# Altium schematic sheet main.SchDoc
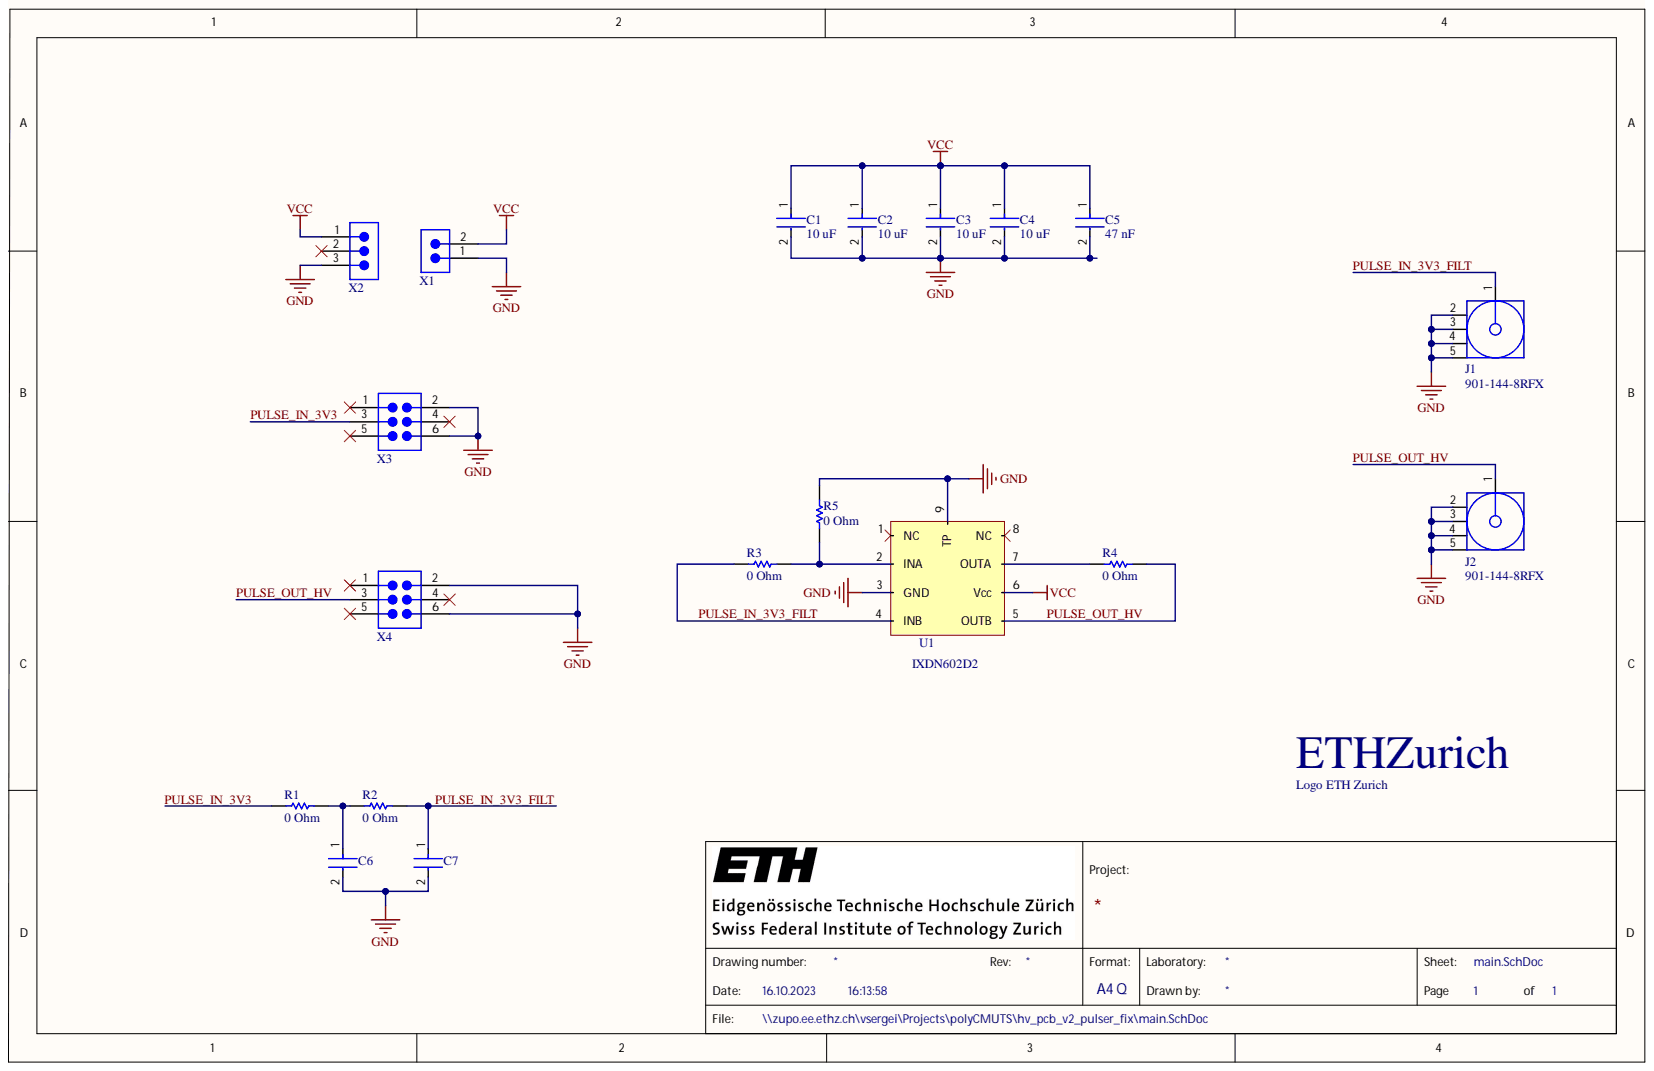
[diagram p0: main.SchDoc]

## Parts
A?  Logo ETH Zurich  [eth-zurich_2mm]  (no BOM)
C1  10 uF  [FP-GRM188-0_2-IPC_C]
C2  10 uF  [FP-GRM188-0_2-IPC_C]
C3  10 uF  [FP-GRM188-0_2-IPC_C]
C4  10 uF  [FP-GRM188-0_2-IPC_C]
C5  47 nF  [FP-CGAEA-MFG]
C6  C0402C100J3GACTU  [FP-C0402-BB-MFG]  (value not drawn)
C7  C0402C100J3GACTU  [FP-C0402-BB-MFG]  (value not drawn)
J1  901-144-8RFX  [AMPH-901-144-8RFX_V]
J2  901-144-8RFX  [AMPH-901-144-8RFX_V]
R1  0 Ohm  [RESC1005X40X25NL05T10]
R2  0 Ohm  [RESC1005X40X25NL05T10]
R3  0 Ohm  [RESC1005X40X25NL05T10]
R4  0 Ohm  [RESC1005X40X25NL05T10]
R5  0 Ohm  [RESC1005X40X25NL05T10]
U1  IXDN602D2  [IXDN602D2]
X1  68000-102HLF  [68000-102HLF]  (value not drawn)
X2  68000-103HLF  [68000-103HLF]  (value not drawn)
X3  67996-106HLF  [67996-106HLF]  (value not drawn)
X4  67996-106HLF  [67996-106HLF]  (value not drawn)

## Nets
GND: C1.2 C2.2 C3.2 C4.2 C5.2 C6.2 C7.2 J1.2 J1.3 J1.4 J1.5 J2.2 J2.3 J2.4 J2.5 R5.2 U1.3(GND) U1.9(TP) X1.1 X2.3 X3.2 X3.6 X4.2 X4.6
VCC: C1.1 C2.1 C3.1 C4.1 C5.1 U1.6(Vcc) X1.2 X2.1
PULSE_IN_3V3: R1.2 X3.3
PULSE_IN_3V3_FILT: C7.1 J1.1 R2.1 R3.1 U1.4(INB)
PULSE_OUT_HV: J2.1 R4.2 U1.5(OUTB) X4.3
NetC6_1: C6.1 R1.1 R2.2
NetR3_2: R3.2 R5.1 U1.2(INA)
NetR4_1: R4.1 U1.7(OUTA)

Not connected: U1 1(NC) 8(NC); X2 2; X3 1 4 5; X4 1 4 5

## Library data of the parts
A? = CMP-00038-1: Library Name: ETHZ Vault
C1-C4 = CMP-06035-042125-1: Chip Multilayer Ceramic Capacitors for General Purpose, 0603, 2.2uF, X5R, 15%, 10%, 50V GRM188R61H225KE11D; Capacitance: 2.2uF; Case Code (Imperial): 0603; Case Code (Metric): 1608; Case/Package: 0603; Component Kind: Standard; Dielectric: X5R; Height: 0.8mm; Length: 0.063inch; Library Name: Altium Content Vault; Material: Ceramic; Max Operating Temperature: 85°C; Min Operating Temperature: -55°C; Mount: Surface Mount; Packaging: Cut Tape; REACH SVHC: No SVHC; Thickness: 0.039inch; Tolerance: 10%; Voltage Rating: 50V; Voltage Rating (DC): 50V; Width: 0.031inch
C5 = CMP-08246-002687-1: CAP CER 0.047UF 50V X7R 0204  CGAEA1X7R1H473M030BC; Component Kind: Standard; Library Name: Altium Content Vault; RoHS Compliant: No
C6 C7 = CMP-1034-00716-4: CAP CER 10PF 25V 5% NP0 0402; Manufacturer: Kemet; Material: Ceramic; Temperature Characteristic: C0G; Temperature Coefficient: 15%; Tolerance: 0.05; Automotive: No; Capacitance: 10 pF; Category: Cap; Component Kind: Standard; ComponentLink2Description: Datasheet; ComponentLink2URL: https://content.kemet.com/datasheets/KEM_C1002_X7R_SMD.pdf; Device Class L1: Passive Components; Device Class L2: Capacitors; Device Class L3: Ceramic Capacitors; Digikey Description: CAP CER 10PF 25V 5% NP0 0402; Height: 0.55mm; Ipc Land Pattern Name: CAPC10050X50; Lead Free: Yes; Library Name: Altium Content Vault; Manufacturer Part Number: C0402C100J3GACTU; Mouser Description: Multilayer Ceramic Capacitors MLCC - SMD/SMT 25volts 10pF C0G 5%; Octopart: https://octopart.com/c0402c100j3gactu-kemet-9138549; Package: C0402-BB; RoHS: TRUE; Temperature: -55°C to +125°C; Temperature Range High: +125°C; Temperature Range Low: -55°C; Value: 10 pF; Voltage Rating: 25 V
J1 J2 = CMP-2000-05706-1: Coaxial Connector, 18 GHz, 50 Ohm, -65 to 165 degC, 5-Pin THD, RoHS, Bulk; Manufacturer: Amphenol; 3D Model: 901-144-8RFX.igs; Body Finish: Gold; Body Material: Brass; Center Contact Material: BerylliumCopper; Connector Style: SMA; Connector Type: Jack,FemaleSocket; Contact Termination: Solder; Dielectric Material: Polytetrafluoroethylene(PTFE); Frequency - Max: 18GHz; Impedance: 50Ohm; Online Catalog: SMA,RFX; Other Names: 901-144-8-RFX9011448RFXARFX1231; Cable Group: -; Category: Connectors,Interconnects; Center Contact Plating: Gold; Component Kind: Standard; Datasheet URL: https://datasheet.ciiva.com/23676/c901-144-8rfx-23676337.pdf; Datasheet Version: Rev. A, 01/2001; Family: CoaxialConnectors(RF); Fastening Type: Threaded; Features: -; Housing Color: Gold; Ingress Protection: -; Library Name: Altium Content Vault; Manufacturer URL: http://www.amphenol.com/; Mounting Technology: SurfaceMount; Mounting Type: ThroughHole; Operating Temperature: -65°C~165°C; Package Description: 5-Pin Through Hole Device, Body 7 x 7 mm, Pitch 2.54 mm; Package Reference: 901-144-8RFX; Packaging: Bulk; Series: -; Shield Termination: Solder; Standard Package: 1; Voltage Rating: -
R1-R5 = CMP-1011-00001-2: Manufacturer: Yageo / Phycomp; Part Number: RC0402JR-070RL; Component Kind: Standard; Library Name: Altium Content Vault
U1 = IXDN602D2: IXD_602  2-Ampere Dual Low-Side  Ultrafast MOSFET Drivers; Component Kind: Standard; Library Name: Schlib.SchLib
X1 = CMP-00100-3: Manufacturer: Amphenol FCI; Connector Type: Male Pin; Current Rating: 3A; Gender: Male; Insulation Resistance: 5GR; Mating Post Length: 5.84mm; Max Voltage Rating (AC): 1.5kV; Number of Contacts: 2; Pitch: 2.54mm; Case/Package: 100; Colour: Black; Component Kind: Standard; ComponentLink1Description: 68000-274228-5149165.pdf; ComponentLink1URL: https://datasheet.ciiva.com/5149/68000-274228-5149165.pdf; ComponentLink2Description: 68000-722084.pdf; ComponentLink2URL: https://datasheet.ciiva.com/722/68000-722084.pdf; ComponentLink3Description: 68000-10149324.pdf; ComponentLink3URL: https://datasheet.ciiva.com/10149/68000-10149324.pdf; ComponentLink4Description: 68000-16726309.pdf; ComponentLink4URL: https://datasheet.ciiva.com/16726/68000-16726309.pdf; Contact Gender: Male; Contact Material: Bronze; Contact Mating Length: 0.23inch; Contact Plating: Nickel,Gold,GXT; Depth: 2.41mm; Flammability Rating: UL94 V-0; Housing Colour: Black; Housing Material: Thermoplastic; Lead Free: Lead Free; Length: 5.08mm; Library Name: ETHZ Vault; Manufacturer Part Number: 68000-102HLF; Max Operating Temperature: 125°C; Min Operating Temperature: -65°C; Number of Positions: 2; Number of Rows: 1; Orientation: Straight; Package Quantity: 100; Packaging: Bulk; Radiation Hardening: No; Supplier: Digikey; Supplier Part Number: 609-3500-ND; Termination: Solder; Termination Post Length: 2.41mm; Voltage Rating: 1.5kV; Voltage Rating (DC): 1.5kV
X2 = CMP-00101-2: 3 Positions Header, Unshrouded Connector 0.100" (2.54mm) Through Hole Gold or Gold, GXT™; Manufacturer: Amphenol FCI; Current Rating: 3A; Insulation Resistance: 5GR; Max Voltage Rating (AC): 1.5kV; Number of Contacts: 3; Color: Black; Component Kind: Standard; ComponentLink1Description: 68000-274283-5157301.pdf; ComponentLink1URL: https://datasheet.ciiva.com/5157/68000-274283-5157301.pdf; ComponentLink2Description: 68000-731071.pdf; ComponentLink2URL: https://datasheet.ciiva.com/731/68000-731071.pdf; ComponentLink3Description: 68000-10149754.pdf; ComponentLink3URL: https://datasheet.ciiva.com/10149/68000-10149754.pdf; ComponentLink4Description: 1914865-46392021.pdf; ComponentLink4URL: https://datasheet.ciiva.com/46392/1914865-46392021.pdf; Connector Type: Header, Unshrouded; Contact Finish: Gold or Gold, GXT™; Contact Finish Thickness: 15µin (0.38µm); Contact Gender: Male; Contact Material: Bronze; Contact Mating Length: 0.230" (5.84mm); Contact Plating: Nickel,Gold,GXT; Contact Type: Male Pin; Depth: 2.41mm; Fastening Type: -; Features: -; Flammability Rating: UL94 V-0; Housing Colour: Black; Housing Material: Thermoplastic; Length: 7.62mm; Library Name: ETHZ Vault; Manufacturer Part Number: 68000-103HLF; Max Operating Temperature: 125°C; Min Operating Temperature: -65°C; Mounting Type: Through Hole; Number of Positions: 3; Number of Rows: 1; Orientation: Straight; Package Quantity: 100; Packaging: Bulk; Pitch: 2.54mm; Radiation Hardening: No; REACH SVHC: No SVHC; Supplier: Digikey; Supplier Part Number: 609-3461-ND; Termination: Solder; Termination Post Length: 2.41mm; Voltage Rating: 1.5kV
X3 X4 = CMP-00150-2: 6 Positions Header, Unshrouded Connector 0.100" (2.54mm) Through Hole Gold or Gold, GXT™; Manufacturer: Amphenol FCI; Connector Type: Male Pin; Current Rating: 3A; Gender: Male; Insulation Resistance: 5GR; Mating Post Length: 5.84mm; Max Voltage Rating (AC): 1.5kV; Number of Contacts: 6; Row Spacing: 0.1inch; Case/Package: 100; Colour: Black; Component Kind: Standard; ComponentLink1Description: 67996-16726269.pdf; ComponentLink1URL: https://datasheet.ciiva.com/16726/67996-16726269.pdf; ComponentLink2Description: 1876884-46393071.pdf; ComponentLink2URL: https://datasheet.ciiva.com/46393/1876884-46393071.pdf; ComponentLink3Description: 67996-728918.pdf; ComponentLink3URL: https://datasheet.ciiva.com/728/67996-728918.pdf; ComponentLink4Description: 67996-10149181.pdf; ComponentLink4URL: https://datasheet.ciiva.com/10149/67996-10149181.pdf; Contact Gender: Male; Contact Material: Bronze; Contact Mating Length: 0.23inch; Contact Plating: Nickel,Gold,GXT; Depth: 4.83mm; Flammability Rating: UL94 V-0; Housing Colour: Black; Housing Material: Thermoplastic; Length: 7.62mm; Library Name: ETHZ Vault; Manufacturer Part Number: 67996-106HLF; Max Operating Temperature: 125°C; Min Operating Temperature: -65°C; Number of Positions: 6; Number of Rows: 2; Orientation: Straight; Package Quantity: 100; Packaging: Bulk; Pitch: 2.54mm; Radiation Hardening: No; REACH SVHC: No SVHC; Supplier: Digikey; Supplier Part Number: 609-3202-ND; Termination: Solder; Termination Post Length: 2.41mm; Voltage Rating: 1.5kV
